# Altium schematic sheet MAIN-RELAYS.SchDoc
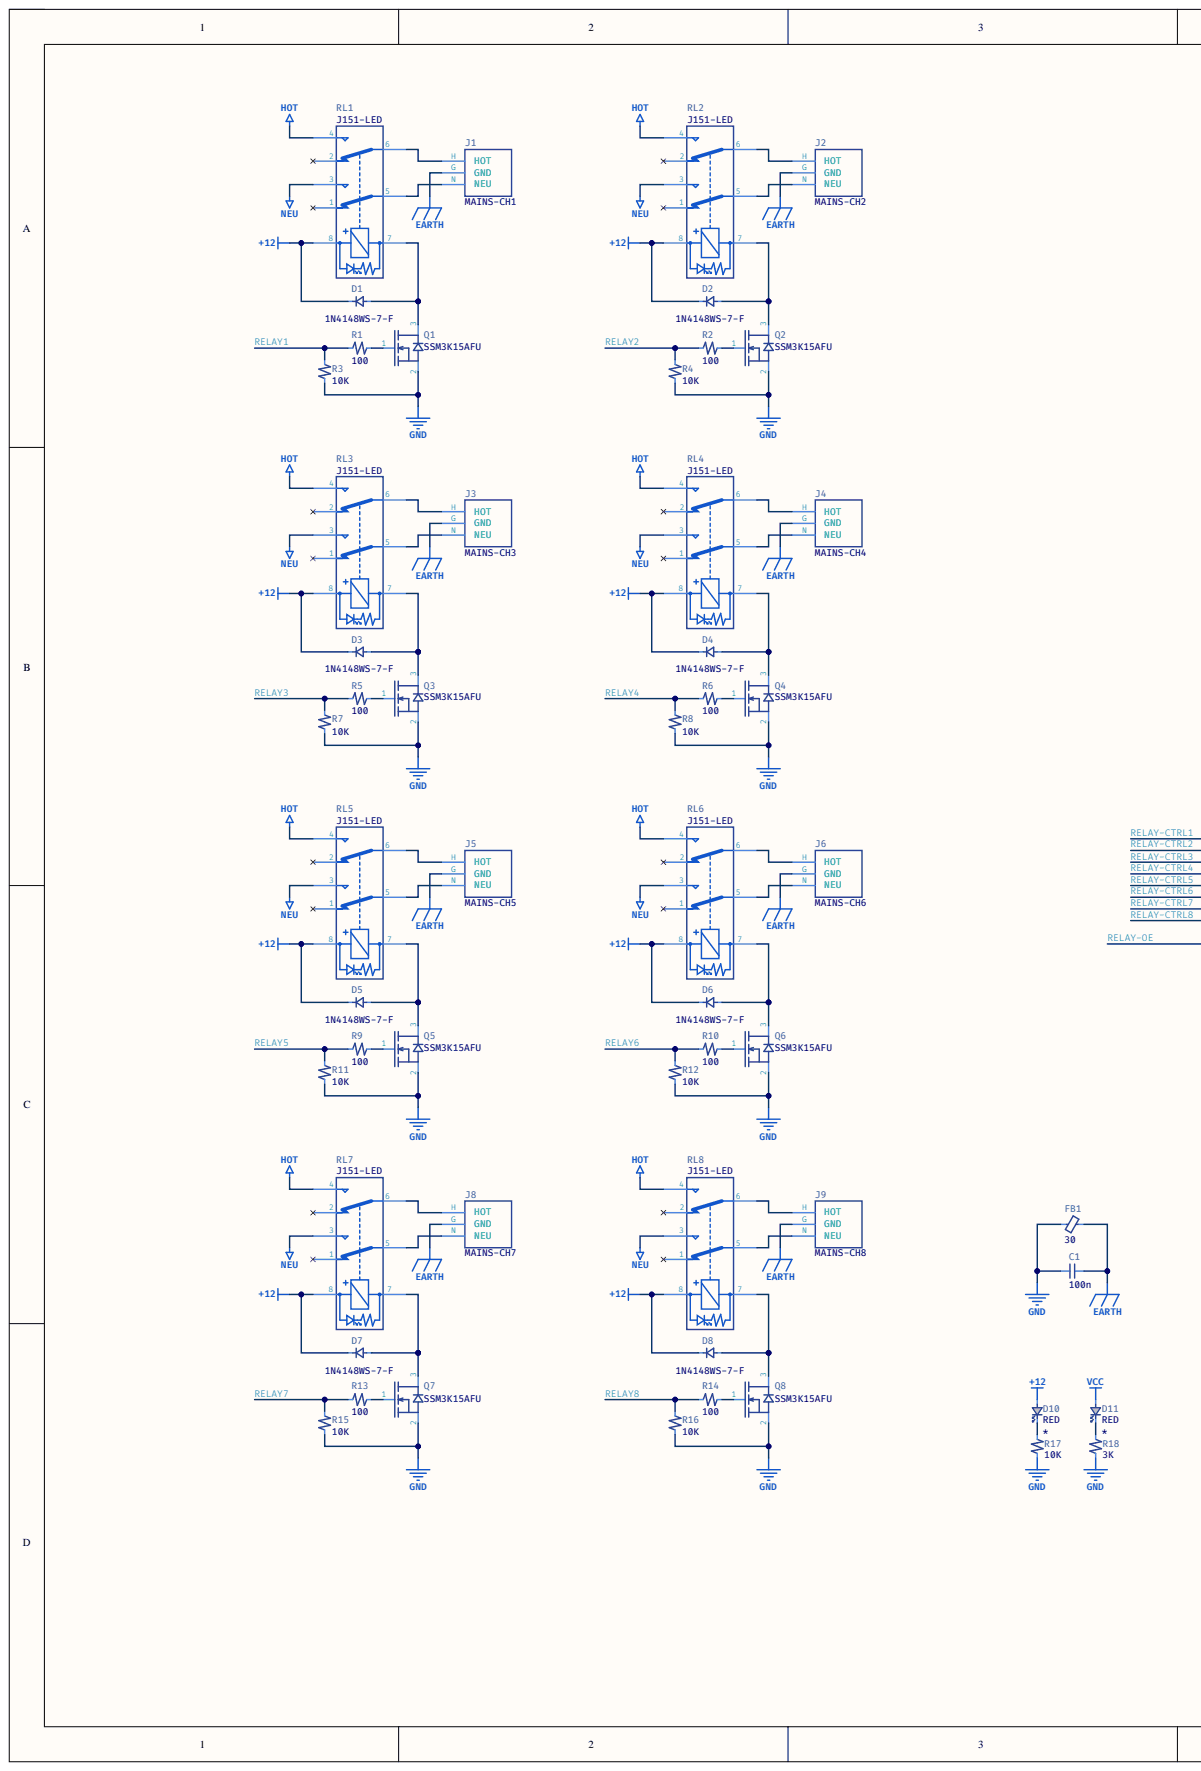
[diagram p0: MAIN-RELAYS.SchDoc, left]
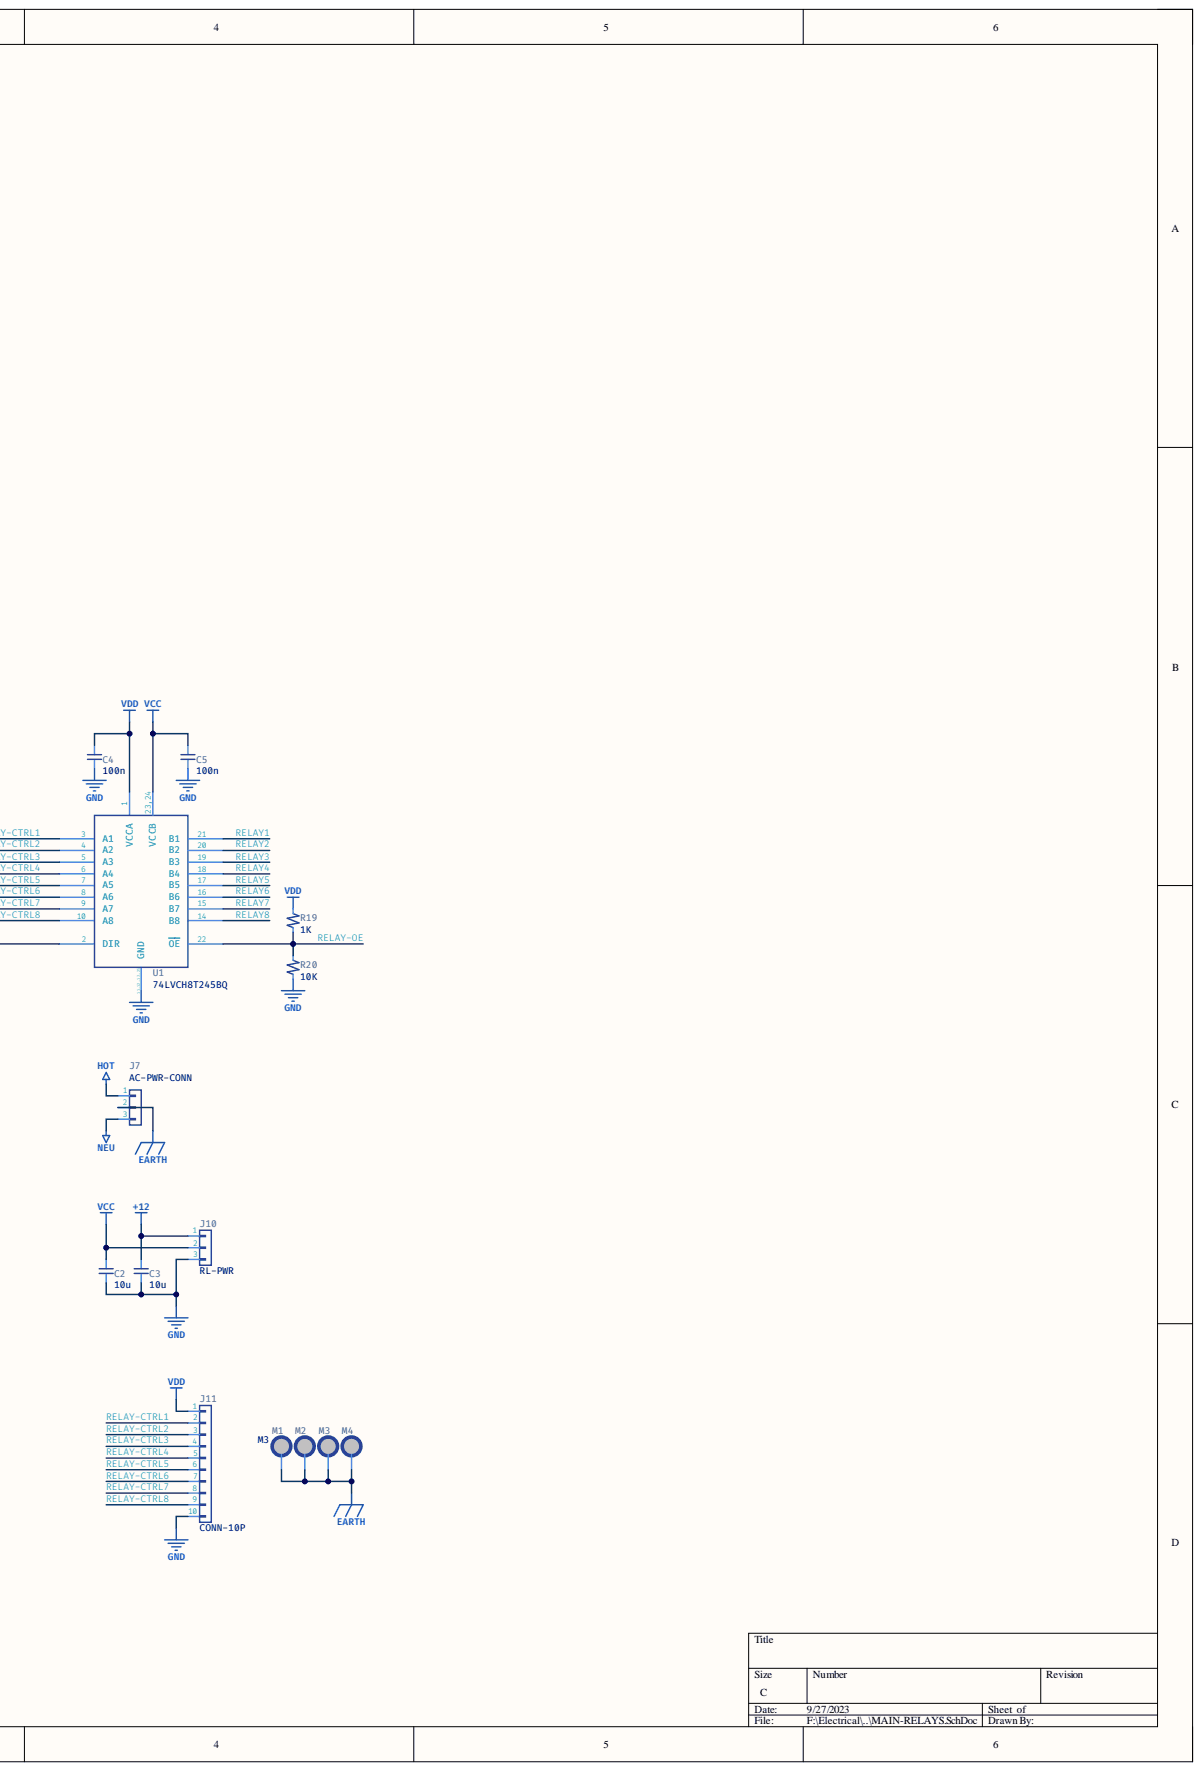
[diagram p1: MAIN-RELAYS.SchDoc, right]

## Parts
C1  value 100n  [CAP-0805]  (hidden comment: Generic Capacitor)
C2  value 10u  [CAP-1210]  (hidden comment: Generic Capacitor)
C3  value 10u  [CAP-1210]  (hidden comment: Generic Capacitor)
C4  value 100n  [CAP-0603]  (hidden comment: Generic Capacitor)
C5  value 100n  [CAP-0603]  (hidden comment: Generic Capacitor)
D1  value 1N4148WS-7-F  [D_SOD-323]  (hidden comment: DIODE GEN PURP 75V 150MA SOD323)
D2  value 1N4148WS-7-F  [D_SOD-323]  (hidden comment: DIODE GEN PURP 75V 150MA SOD323)
D3  value 1N4148WS-7-F  [D_SOD-323]  (hidden comment: DIODE GEN PURP 75V 150MA SOD323)
D4  value 1N4148WS-7-F  [D_SOD-323]  (hidden comment: DIODE GEN PURP 75V 150MA SOD323)
D5  value 1N4148WS-7-F  [D_SOD-323]  (hidden comment: DIODE GEN PURP 75V 150MA SOD323)
D6  value 1N4148WS-7-F  [D_SOD-323]  (hidden comment: DIODE GEN PURP 75V 150MA SOD323)
D7  value 1N4148WS-7-F  [D_SOD-323]  (hidden comment: DIODE GEN PURP 75V 150MA SOD323)
D8  value 1N4148WS-7-F  [D_SOD-323]  (hidden comment: DIODE GEN PURP 75V 150MA SOD323)
D10  Color: RED  [LED-0805]  (hidden comment: General LED)
D11  Color: RED  [LED-0805]  (hidden comment: General LED)
FB1  value 30  [IND-0805]  (hidden comment: Generic Ferrite Bead)
J1  value MAINS-CH1  [BENCHY-MAINS-PLUG]  (hidden comment: 3-PIN-AC-DIRECT)
J2  value MAINS-CH2  [BENCHY-MAINS-PLUG]  (hidden comment: 3-PIN-AC-DIRECT)
J3  value MAINS-CH3  [BENCHY-MAINS-PLUG]  (hidden comment: 3-PIN-AC-DIRECT)
J4  value MAINS-CH4  [BENCHY-MAINS-PLUG]  (hidden comment: 3-PIN-AC-DIRECT)
J5  value MAINS-CH5  [BENCHY-MAINS-PLUG]  (hidden comment: 3-PIN-AC-DIRECT)
J6  value MAINS-CH6  [BENCHY-MAINS-PLUG]  (hidden comment: 3-PIN-AC-DIRECT)
J7  value AC-PWR-CONN  [PhoenixContact_MSTBVA_2,5_3-G_1x03_P5.00mm_Vertical]  (hidden comment: Conn_01x03)
J8  value MAINS-CH7  [BENCHY-MAINS-PLUG]  (hidden comment: 3-PIN-AC-DIRECT)
J9  value MAINS-CH8  [BENCHY-MAINS-PLUG]  (hidden comment: 3-PIN-AC-DIRECT)
J10  value RL-PWR  [JST_XH_B3B-XH-A_1x03_P2.50mm_Vertical]  (hidden comment: RELAYS PWR CONN)
J11  value CONN-10P  [JST_XH_B10B-XH-A_1x10_P2.50mm_Vertical]  (hidden comment: Conn_01x10)
M1  value M3  [PTH-MOUNT-M3-VIA]  (hidden comment: Plated Mounting Hole)
M2  Plated Mounting Hole  [PTH-MOUNT-M3-VIA]  (value not drawn)
M3  value M3  [PTH-MOUNT-M3-VIA]  (hidden comment: Plated Mounting Hole)
M4  Plated Mounting Hole  [PTH-MOUNT-M3-VIA]  (value not drawn)
Q1  value SSM3K15AFU  [SOT-323_SC-70]  (hidden comment: N-Ch SIG MOSFET 30V 100MA)
Q2  value SSM3K15AFU  [SOT-323_SC-70]  (hidden comment: N-Ch SIG MOSFET 30V 100MA)
Q3  value SSM3K15AFU  [SOT-323_SC-70]  (hidden comment: N-Ch SIG MOSFET 30V 100MA)
Q4  value SSM3K15AFU  [SOT-323_SC-70]  (hidden comment: N-Ch SIG MOSFET 30V 100MA)
Q5  value SSM3K15AFU  [SOT-323_SC-70]  (hidden comment: N-Ch SIG MOSFET 30V 100MA)
Q6  value SSM3K15AFU  [SOT-323_SC-70]  (hidden comment: N-Ch SIG MOSFET 30V 100MA)
Q7  value SSM3K15AFU  [SOT-323_SC-70]  (hidden comment: N-Ch SIG MOSFET 30V 100MA)
Q8  value SSM3K15AFU  [SOT-323_SC-70]  (hidden comment: N-Ch SIG MOSFET 30V 100MA)
R1  value 100  [RES-0603]  (hidden comment: General Resistor)
R2  value 100  [RES-0603]  (hidden comment: General Resistor)
R3  value 10K  [RES-0603]  (hidden comment: General Resistor)
R4  value 10K  [RES-0603]  (hidden comment: General Resistor)
R5  value 100  [RES-0603]  (hidden comment: General Resistor)
R6  value 100  [RES-0603]  (hidden comment: General Resistor)
R7  value 10K  [RES-0603]  (hidden comment: General Resistor)
R8  value 10K  [RES-0603]  (hidden comment: General Resistor)
R9  value 100  [RES-0603]  (hidden comment: General Resistor)
R10  value 100  [RES-0603]  (hidden comment: General Resistor)
R11  value 10K  [RES-0603]  (hidden comment: General Resistor)
R12  value 10K  [RES-0603]  (hidden comment: General Resistor)
R13  value 100  [RES-0603]  (hidden comment: General Resistor)
R14  value 100  [RES-0603]  (hidden comment: General Resistor)
R15  value 10K  [RES-0603]  (hidden comment: General Resistor)
R16  value 10K  [RES-0603]  (hidden comment: General Resistor)
R17  value 10K  [RES-0603]  (hidden comment: General Resistor)
R18  value 3K  [RES-0603]  (hidden comment: General Resistor)
R19  value 1K  [RES-0603]  (hidden comment: General Resistor)
R20  value 10K  [RES-0603]  (hidden comment: General Resistor)
RL1  value J151-LED  [J151-LUG]  (hidden comment: CIT J151 SERIES W/ LED DPDT Relay)
RL2  value J151-LED  [J151-LUG]  (hidden comment: CIT J151 SERIES W/ LED DPDT Relay)
RL3  value J151-LED  [J151-LUG]  (hidden comment: CIT J151 SERIES W/ LED DPDT Relay)
RL4  value J151-LED  [J151-LUG]  (hidden comment: CIT J151 SERIES W/ LED DPDT Relay)
RL5  value J151-LED  [J151-LUG]  (hidden comment: CIT J151 SERIES W/ LED DPDT Relay)
RL6  value J151-LED  [J151-LUG]  (hidden comment: CIT J151 SERIES W/ LED DPDT Relay)
RL7  value J151-LED  [J151-LUG]  (hidden comment: CIT J151 SERIES W/ LED DPDT Relay)
RL8  value J151-LED  [J151-LUG]  (hidden comment: CIT J151 SERIES W/ LED DPDT Relay)
U1  value 74LVCH8T245BQ  [DHVQFN-24-5.5x3.5-EP1-P0.5]  (hidden comment: IC TRANSLATR TXRX 1:8 5.5V)

## Nets
+12: C3.1 D1.1 D2.1 D3.1 D4.1 D5.1 D6.1 D7.1 D8.1 D10.2 J10.1 RL1.8 RL2.8 RL3.8 RL4.8 RL5.8 RL6.8 RL7.8 RL8.8
EARTH: C1.2 FB1.2 J1.G J2.G J3.G J4.G J5.G J6.G J7.2 J8.G J9.G M1.1 M2.1 M3.1 M4.1
GND: C1.1 C2.2 C3.2 C4.2 C5.2 FB1.1 J10.3 J11.10 Q1.2 Q2.2 Q3.2 Q4.2 Q5.2 Q6.2 Q7.2 Q8.2 R3.1 R4.1 R7.1 R8.1 R11.1 R12.1 R15.1 R16.1 R17.1 R18.1 R20.1 U1.11,12,13,25(GND)
HOT: J7.1 RL1.4 RL2.4 RL3.4 RL4.4 RL5.4 RL6.4 RL7.4 RL8.4
NEU: J7.3 RL1.3 RL2.3 RL3.3 RL4.3 RL5.3 RL6.3 RL7.3 RL8.3
VCC: C2.1 C5.1 D11.2 J10.2 U1.23,24(VCCB)
VDD: C4.1 J11.1 R19.2 U1.1(VCCA)
RELAY1: R1.1 R3.2 U1.21(B1)
RELAY2: R2.1 R4.2 U1.20(B2)
RELAY3: R5.1 R7.2 U1.19(B3)
RELAY4: R6.1 R8.2 U1.18(B4)
RELAY5: R9.1 R11.2 U1.17(B5)
RELAY6: R10.1 R12.2 U1.16(B6)
RELAY7: R13.1 R15.2 U1.15(B7)
RELAY8: R14.1 R16.2 U1.14(B8)
RELAY-CTRL1: J11.2 U1.3(A1)
RELAY-CTRL2: J11.3 U1.4(A2)
RELAY-CTRL3: J11.4 U1.5(A3)
RELAY-CTRL4: J11.5 U1.6(A4)
RELAY-CTRL5: J11.6 U1.7(A5)
RELAY-CTRL6: J11.7 U1.8(A6)
RELAY-CTRL7: J11.8 U1.9(A7)
RELAY-CTRL8: J11.9 U1.10(A8)
RELAY-OE: R19.1 R20.2 U1.2(DIR) U1.22(OE)
NetD1_2: D1.2 Q1.3 RL1.7
NetD2_2: D2.2 Q2.3 RL2.7
NetD3_2: D3.2 Q3.3 RL3.7
NetD4_2: D4.2 Q4.3 RL4.7
NetD5_2: D5.2 Q5.3 RL5.7
NetD6_2: D6.2 Q6.3 RL6.7
NetD7_2: D7.2 Q7.3 RL7.7
NetD8_2: D8.2 Q8.3 RL8.7
NetD10_1: D10.1 R17.2
NetD11_1: D11.1 R18.2
NetJ1_H: J1.H RL1.6
NetJ1_N: J1.N RL1.5
NetJ2_H: J2.H RL2.6
NetJ2_N: J2.N RL2.5
NetJ3_H: J3.H RL3.6
NetJ3_N: J3.N RL3.5
NetJ4_H: J4.H RL4.6
NetJ4_N: J4.N RL4.5
NetJ5_H: J5.H RL5.6
NetJ5_N: J5.N RL5.5
NetJ6_H: J6.H RL6.6
NetJ6_N: J6.N RL6.5
NetJ8_H: J8.H RL7.6
NetJ8_N: J8.N RL7.5
NetJ9_H: J9.H RL8.6
NetJ9_N: J9.N RL8.5
NetQ1_1: Q1.1 R1.2
NetQ2_1: Q2.1 R2.2
NetQ3_1: Q3.1 R5.2
NetQ4_1: Q4.1 R6.2
NetQ5_1: Q5.1 R9.2
NetQ6_1: Q6.1 R10.2
NetQ7_1: Q7.1 R13.2
NetQ8_1: Q8.1 R14.2

Not connected: RL1 1 2; RL2 1 2; RL3 1 2; RL4 1 2; RL5 1 2; RL6 1 2; RL7 1 2; RL8 1 2

## Library data of the parts
C1-C5 = C: General un-polarized capacitor; Tolerance: 5%; Component Kind: Standard; Library Name: Daxxn_Device.SchLib
D1-D8 = D-GP: General purpose doide; Component Kind: Standard; ComponentLink1Description: Datasheet; ComponentLink1URL: https://www.diodes.com/assets/Datasheets/1N4148WS_BAV16WS.pdf; ComponentLink2Description: LocalDatasheet; Supplier 1: Digi-Key; Supplier 2: Digi-Key; Supplier 3: Digi-Key; Supplier 4: Mouser; Supplier 5: Newark; Supplier 6: Newark; Supplier Part Number 1: 1N4148WS-FDICT-ND; Supplier Part Number 2: 1N4148WS-FDIDKR-ND; Supplier Part Number 3: 1N4148WS-FDITR-ND; Supplier Part Number 4: 621-1N4148WS-F; Supplier Part Number 5: 12T2305; Supplier Part Number 6: 84AC3369; Library Name: Daxxn_Device.SchLib
D10 D11 = LED: Component Kind: Standard; ComponentLink1Description: Datasheet; ComponentLink2Description: LocalDatasheet; Description: General LED; Library Name: Daxxn_Device.SchLib
FB1 = FerriteBead: Component Kind: Standard; Description: Generic Ferrite Bead; Library Name: Daxxn_Device.SchLib
J10 = Conn_01x03: Component Kind: Standard; ComponentLink1Description: Datasheet; ComponentLink2Description: LocalDatasheet; Library Name: Connector_Generic.SchLib
M1 M3 = MountingHole-PTH: Generic Plated Mounting Through Hole; Component Kind: Standard; ComponentLink1Description: Datasheet; ComponentLink2Description: LocalDatasheet; Library Name: Daxxn_Device.SchLib
M2 M4 = MountingHole-PTH: Generic Plated Mounting Through Hole; Value: M3; Component Kind: Standard; ComponentLink1Description: Datasheet; ComponentLink2Description: LocalDatasheet; Library Name: Daxxn_Device.SchLib
Q1-Q8 = SSM3K15AFU: N-Channel 30 V 100mA (Ta) 150mW (Ta) Surface Mount; Component Kind: Standard; ComponentLink1Description: Datasheet; ComponentLink1URL: https://toshiba.semicon-storage.com/info/SSM3K15AFU_datasheet_en_20140301.pdf?did=5878&prodName=SSM3K15AFU; ComponentLink2Description: LocalDatasheet; Supplier 1: Digi-Key; Supplier 2: Digi-Key; Supplier 3: Digi-Key; Supplier 4: Mouser; Supplier Part Number 1: SSM3K15AFULFCT-ND; Supplier Part Number 2: SSM3K15AFULFDKR-ND; Supplier Part Number 3: SSM3K15AFULFTR-ND; Supplier Part Number 4: 757-SSM3K15AFULF; ComponentLink2URL: F:\Electrical\Datasheets\SSM3K15AFU.pdf; Library Name: Daxxn_Transistors.SchLib
R1-R20 = R: General purpose resistor; Tolerance: 1%; Component Kind: Standard; Library Name: Daxxn_Device.SchLib
RL1-RL8 = Relay-J151-LED: CIT J151 series DPDT relay with built-in LED; Component Kind: Standard; ComponentLink1Description: Datasheet; ComponentLink1URL: https://www.citrelay.com/Catalog%20Pages/RelayCatalog/J151.pdf; ComponentLink2Description: LocalDatasheet; Library Name: Relay.SchLib; ComponentLink2URL: F:\Electrical\Datasheets\J151.pdf
U1 = 74LVCH8T245BQ: Translation Transceiver 1 Element 8 Bit per Element 3-State Output DHVQFN-24; Component Kind: Standard; ComponentLink1Description: Datasheet; ComponentLink1URL: https://assets.nexperia.com/documents/data-sheet/74LVC_LVCH8T245.pdf; ComponentLink2Description: LocalDatasheet; Supplier 1: Digi-Key; Supplier 2: Digi-Key; Supplier 3: Digi-Key; Supplier 4: Newark; Supplier 5: Mouser; Supplier Part Number 1: 1727-4418-1-ND; Supplier Part Number 2: 1727-4418-6-ND; Supplier Part Number 3: 1727-4418-2-ND; Supplier Part Number 4: 74AH2500; Supplier Part Number 5: 771-74LVCH8T245BQ118; ComponentLink2URL: F:\Electrical\Datasheets\74LVC8T245.pdf; Library Name: Daxxn_Logic.SchLib
J1-J6 J8 J9 = 3-PIN-AC-DIRECT: Component Kind: Standard; ComponentLink1Description: Datasheet; ComponentLink2Description: LocalDatasheet; Library Name: Daxxn_Connectors.SchLib
J7 = Conn_01x03: Component Kind: Standard; ComponentLink1Description: Datasheet; ComponentLink2Description: LocalDatasheet; Library Name: Connector_Generic.SchLib
J11 = Conn_01x10: Component Kind: Standard; ComponentLink1Description: Datasheet; ComponentLink2Description: LocalDatasheet; Library Name: Connector_Generic.SchLib
